# Altium schematic sheet ble.SchDoc
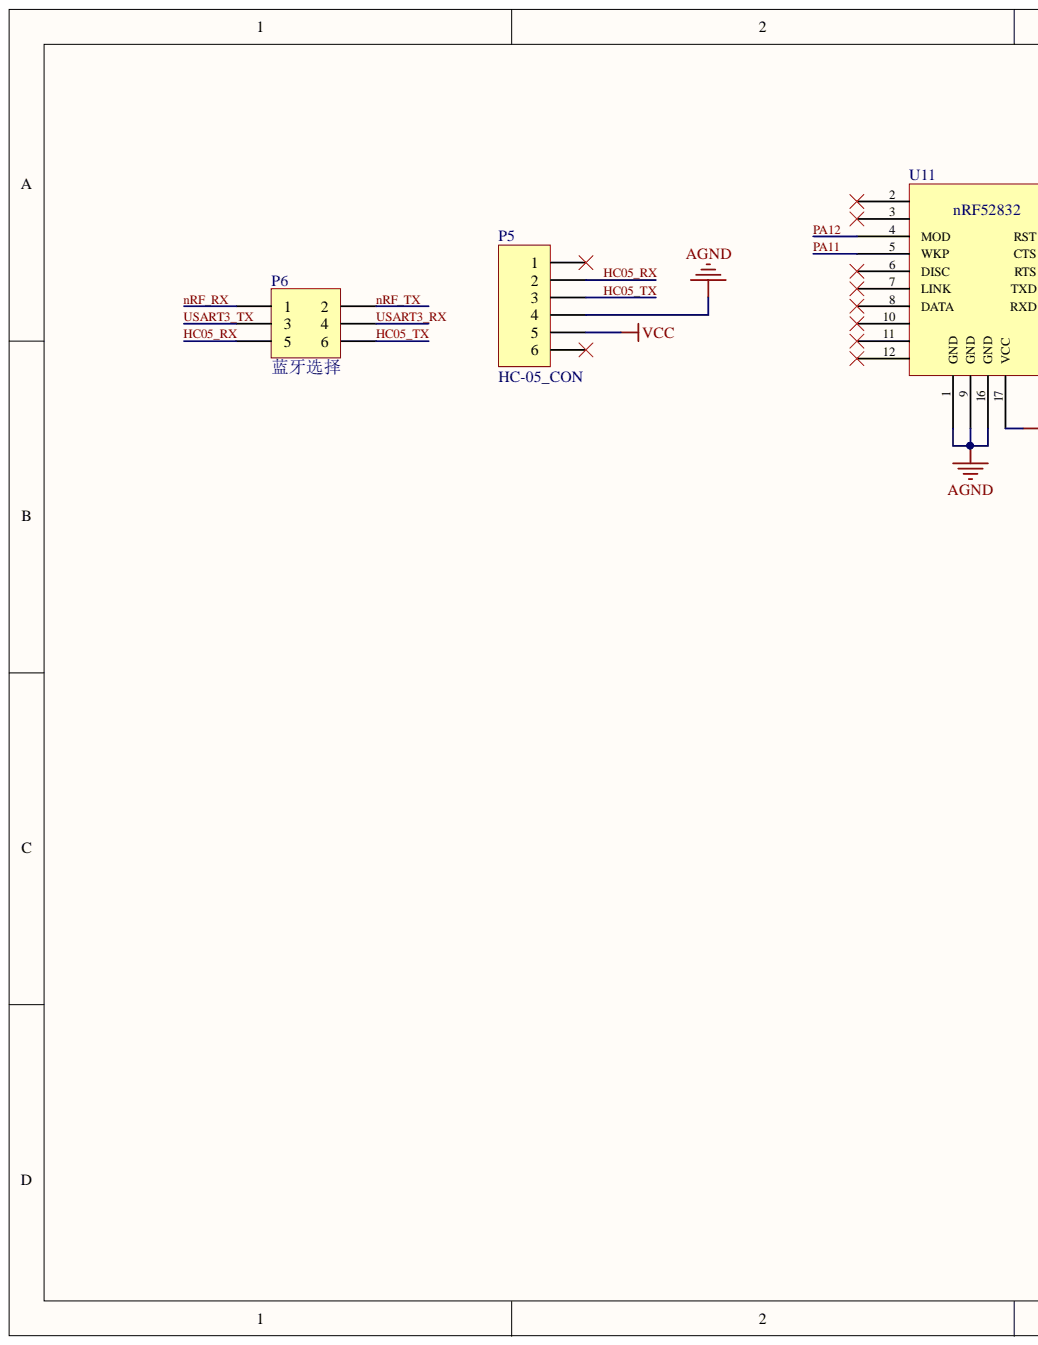
[diagram p0: ble.SchDoc, left]
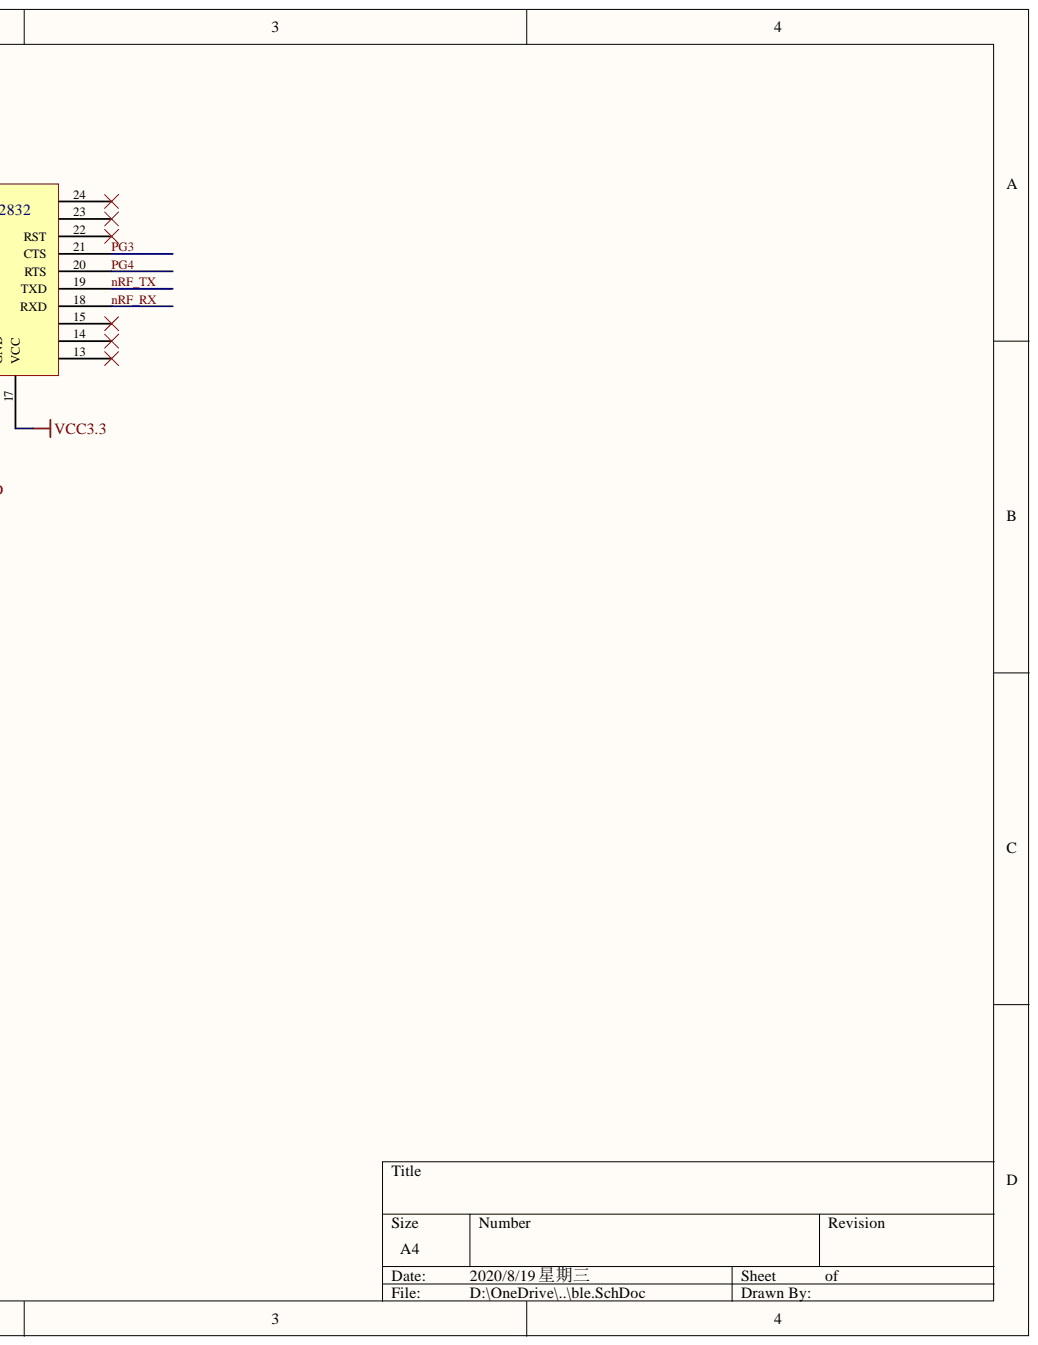
[diagram p1: ble.SchDoc, right]

## Parts
P5  HC-05_CON  [HDR1X6]
P6  蓝牙选择  [HDR2X3]
U11  nRF52832  [nRF52832]

## Nets
AGND: P5.4 U11.1(GND) U11.9(GND) U11.16(GND)
VCC: P5.5
VCC3.3: U11.17(VCC)
HC05_RX: P5.2 P6.5
HC05_TX: P5.3 P6.6
nRF_RX: P6.1 U11.18(RXD)
nRF_TX: P6.2 U11.19(TXD)
PA11: U11.5(WKP)
PA12: U11.4(MOD)
PG3: U11.21(CTS)
PG4: U11.20(RTS)
USART3_RX: P6.4
USART3_TX: P6.3

Not connected: P5 1 6; U11 2 3 6(DISC) 7(LINK) 8(DATA) 10 11 12 13 14 15 22(RST) 23 24

## Library data of the parts
P5 = Header 6: Header, 6-Pin; Component Kind: Standard; LatestRevisionDate: 17-Jul-2002; LatestRevisionNote: Re-released for DXP Platform.; Library Name: Miscellaneous Connectors.IntLib; Signal Integrity: Connector; Publisher: Altium Limited
P6 = Header 3X2: Header, 3-Pin, Dual row; Component Kind: Standard; LatestRevisionDate: 17-Jul-2002; LatestRevisionNote: Re-released for DXP Platform.; Library Name: Miscellaneous Connectors.IntLib; Signal Integrity: Connector; Publisher: Altium Limited
U11 = nRF52832: Component Kind: Standard; Library Name: EEG.SchLib
